# Altium schematic sheet [04] - XBEE.SchDoc("[04] - XBEE")
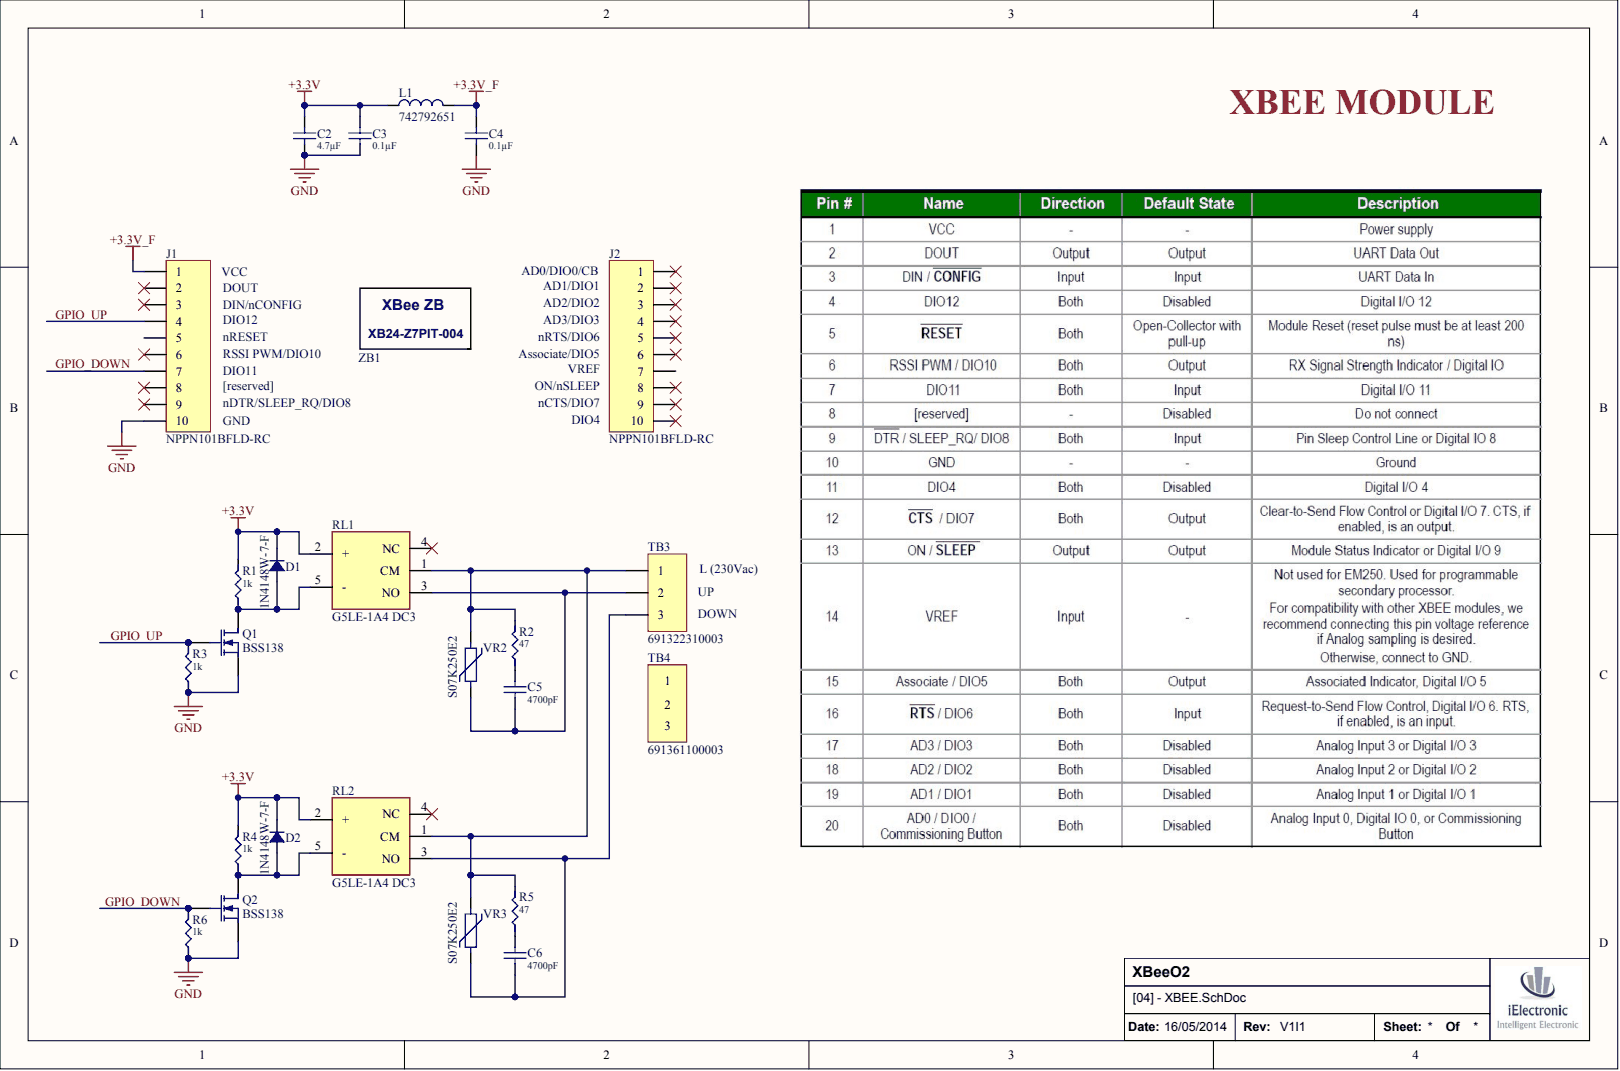
[diagram p0: [04] - XBEE.SchDoc]

## Parts
C2  Capacitance: 4.7µF  (hidden comment: CC0603KRX5R6BB475)
C3  Capacitance: 0.1µF  (hidden comment: CC0402KRX5R6BB104)
C4  Capacitance: 0.1µF  (hidden comment: CC0402KRX5R6BB104)
C5  Capacitance: 4700pF  (hidden comment: CD12ZU2GA472MYNKA)
C6  Capacitance: 4700pF  (hidden comment: CD12ZU2GA472MYNKA)
D1  1N4148W-7-F
D2  1N4148W-7-F
J1  NPPN101BFLD-RC
J2  NPPN101BFLD-RC
L1  742792651
Q1  BSS138
Q2  BSS138
R1  Resistance (Ohms): 1k  (hidden comment: ERJ-3GEYJ102V)
R2  RSF100JB-73-47R  (value not drawn)
R3  Resistance (Ohms): 1k  (hidden comment: ERJ-3GEYJ102V)
R4  Resistance (Ohms): 1k  (hidden comment: ERJ-3GEYJ102V)
R5  RSF100JB-73-47R  (value not drawn)
R6  Resistance (Ohms): 1k  (hidden comment: ERJ-3GEYJ102V)
RL1  G5LE-1A4 DC3
RL2  G5LE-1A4 DC3
TB3  691322310003
TB4  691361100003
VR2  S07K250E2
VR3  S07K250E2
ZB1  XB24-Z7PIT-004

## Nets
+3.3V: C2.2 C3.2 D1.2 D2.2 L1.1 R1.2 R4.2 RL1.2(+) RL2.2(+)
+3.3V_F: C4.2 J1.1 L1.2
GND: C2.1 C3.1 C4.1 J1.10 Q1.3 Q2.3 R3.1 R6.1
GPIO_DOWN: J1.7 Q2.2 R6.2
GPIO_UP: J1.4 Q1.2 R3.2
NetC5_1: C5.1 RL1.3(NO) TB3.2 VR2.1
NetC5_2: C5.2 R2.1
NetC6_1: C6.1 RL2.3(NO) TB3.3 VR3.1
NetC6_2: C6.2 R5.1
NetD1_1: D1.1 Q1.1 R1.1 RL1.5(-)
NetD2_1: D2.1 Q2.1 R4.1 RL2.5(-)
NetR2_2: R2.2 R5.2 RL1.1(CM) RL2.1(CM) TB3.1 VR2.2 VR3.2

Not connected: J1 2 3 5 6 8 9; J2 1 2 3 4 5 6 7 8 9 10; RL1 4(NC); RL2 4(NC)

## Library data of the parts
C2-C4 = (no symbol name): Manufacturer: Yageo; Voltage - Rated: 10V; Tolerance: ±10%; Temperature Coefficient: X5R; .Creator: OGF; Supplier 1: Digi-Key; RoHS: RoHS Compliant; Category: Capacitors; Packaging: Cut Tape (CT); Mounting Type: Surface Mount, MLCC; Operating Temperature: -55°C ~ 85°C; Applications: General Purpose; Ratings: -; Height - Seated (Max): -; Lead Spacing: -; Features: -; Lead Style: -; ComponentLink1URL: http://www.yageo.com/documents/recent/UPY-GPHC_X5R_4V-to-50V_12.pdf; ComponentLink1Description: http://www.yageo.com/documents/recent/UPY-GPHC_X5R_4V-to-50V_12.pdf
  C2: Manufacturer Part Number: CC0603KRX5R6BB475; Stock 1: 2745; Pricing 1: 1=0.15, 10=0.104, 25=0.0756, 100=0.0529, 250=0.04284, 500=0.0378, 1000=0.03214 (EUR); Package / Case: 0603 (1608 Metric); Size / Dimension: 0.063" L x 0.031" W (1.60mm x 0.80mm); Thickness (Max): 0.035" (0.90mm); Supplier Part Number 1: 311-1455-1-ND
  C3 C4: Manufacturer Part Number: CC0402KRX5R6BB104; Stock 1: 928398; Pricing 1: 1=0.08, 10=0.015, 25=0.0104, 100=0.0069, 250=0.00564, 500=0.0048, 1000=0.00374, 2500=0.00336, 5000=0.00311 (EUR); Package / Case: 0402 (1005 Metric); Size / Dimension: 0.039" L x 0.020" W (1.00mm x 0.50mm); Thickness (Max): 0.022" (0.55mm); Supplier Part Number 1: 311-1336-1-ND
C5 C6 = (no symbol name): Manufacturer: TDK Corporation; Voltage - Rated: 400VAC; Tolerance: ±20%; Temperature Coefficient: Z5U; Ratings: X1Y1; .Creator: OGF; Supplier 1: Digi-Key; Manufacturer Part Number: CD12ZU2GA472MYNKA; RoHS: RoHS Compliant; Category: Capacitors; Stock 1: 975; Pricing 1: 1=0.5, 10=0.356, 100=0.2348, 250=0.20004, 500=0.17394, 1000=0.14784, 5000=0.13045, 10000=0.12176, 25000=0.11741 (EUR); Packaging: Bulk; Mounting Type: Through Hole; Operating Temperature: -25°C ~ 125°C; Applications: Safety; Package / Case: Radial, Disc; Size / Dimension: 0.531" Dia (13.50mm); Height - Seated (Max): 0.688" (17.50mm); Thickness (Max): -; Lead Spacing: 0.394" (10.00mm); Features: High Voltage; Lead Style: Straight; ComponentLink1URL: http://product.tdk.com/capacitor/leaddisk/en/documents/leaddisc_commercial_cd_en.pdf; ComponentLink1Description: http://product.tdk.com/capacitor/leaddisk/en/documents/leaddisc_commercial_cd_en.pdf; Supplier Part Number 1: 445-15844-ND
D1 D2 = (no symbol name): Manufacturer: Diodes Inc; Diode Type: Standard; Voltage - DC Reverse (Vr) (Max): 100V; Current - Average Rectified (Io): 150mA; Voltage - Forward (Vf) (Max) @ If: 1.25V @ 150mA; Speed: Small Signal =< 200mA (Io), Any Speed; Reverse Recovery Time (trr): 4ns; Current - Reverse Leakage @ Vr: 1µA @ 75V; Capacitance @ Vr, F: 2pF @ 0V, 1MHz; Thermal Resistance: 315°C/W Ja; .Creator: OGF; Supplier 1: Digi-Key; Manufacturer Part Number: 1N4148W-7-F; RoHS: RoHS Compliant; Category: Discrete Semiconductor Products; Stock 1: 610647; Pricing 1: 1=0.14, 10=0.125, 25=0.1132, 100=0.0815, 250=0.04796, 500=0.0398, 1000=0.02714 (EUR); Packaging: Cut Tape (CT); Mounting Type: Surface Mount; Package / Case: SOD-123; Supplier Device Package: SOD-123; Operating Temperature - Junction: -65°C ~ 150°C; ComponentLink1URL: http://www.diodes.com/datasheets/ds30086.pdf; ComponentLink1Description: http://www.diodes.com/datasheets/ds30086.pdf; Supplier Part Number 1: 1N4148W-FDICT-ND
J1 J2 = (no symbol name): Manufacturer: Sullins Connector Solutions; Connector Type: Header; Number of Positions: 10; Number of Positions Loaded: All; Pitch: 0.079" (2.00mm); .Creator: OGF; Supplier 1: Digi-Key; Manufacturer Part Number: NPPN101BFLD-RC; RoHS: RoHS Compliant; Category: Connectors, Interconnects; Stock 1: 8310; Pricing 1: 1=1.22, 10=1.013, 25=0.938, 50=0.8442, 100=0.7505, 250=0.65672, 500=0.6004, 1000=0.54412, 2500=0.48783 (EUR); Packaging: Bulk; Contact Type: Female Socket; Number of Rows: 1; Row Spacing: -; Mounting Type: Surface Mount; Termination: Solder; Fastening Type: -; Features: -; Contact Finish: Gold; Contact Finish Thickness: Flash; Color: Black; ComponentLink1URL: http://www.sullinscorp.com/images/NPPNxx1BFLx-RC-10489.pdf; ComponentLink1Description: http://www.sullinscorp.com/images/NPPNxx1BFLx-RC-10489.pdf; Supplier Part Number 1: S5902-10-ND
L1 = (no symbol name): Manufacturer: Wurth Electronics Inc; Impedance @ Frequency: 600 Ohm @ 100MHz; Current Rating: 1A; DC Resistance (DCR): 200 mOhm Max; Filter Type: Differential Mode - Single; .Creator: OGF; Supplier 1: Digi-Key; Manufacturer Part Number: 742792651; RoHS: RoHS Compliant; Category: Filters; Stock 1: 98518; Pricing 1: 1=0.34, 10=0.319, 25=0.2976, 100=0.2816, 250=0.26532, 500=0.2545, 1000=0.23824 (EUR); Packaging: Digi-Reel®; Package / Case: 0603 (1608 Metric); Mounting Type: Surface Mount; Height (Max): 0.039" (1.00mm); Size / Dimension: 0.063" L x 0.031" W (1.60mm x 0.80mm); ComponentLink1URL: http://katalog.we-online.de/pbs/datasheet/742792651.pdf; ComponentLink1Description: http://katalog.we-online.de/pbs/datasheet/742792651.pdf; Supplier Part Number 1: 732-1593-6-ND
Q1 Q2 = (no symbol name): Manufacturer: Fairchild Semiconductor; FET Type: MOSFET N-Channel, Metal Oxide; FET Feature: Logic Level Gate; Drain to Source Voltage (Vdss): 50V; Current - Continuous Drain (Id) @ 25° C: 220mA (Ta); Rds On (Max) @ Id, Vgs: 3.5 Ohm @ 220mA, 10V; Vgs(th) (Max) @ Id: 1.5V @ 1mA; Gate Charge (Qg) @ Vgs: 2.4nC @ 10V; Input Capacitance (Ciss) @ Vds: 27pF @ 25V; Power - Max: 360mW; .Creator: OGF; Supplier 1: Digi-Key; RoHS: RoHS Compliant; Category: Discrete Semiconductor Products; Stock 1: 58865; Pricing 1: 1=0.18, 10=0.157, 25=0.1124, 100=0.0875, 250=0.05496, 500=0.04686, 1000=0.03193 (EUR); Packaging: Cut Tape (CT); Mounting Type: Surface Mount; Package / Case: TO-236-3, SC-59, SOT-23-3; Supplier Device Package: SOT-23; ComponentLink1URL: http://www.fairchildsemi.com/ds/BS/BSS138.pdf; ComponentLink1Description: http://www.fairchildsemi.com/ds/BS/BSS138.pdf; Supplier Part Number 1: BSS138CT-ND; Manufacturer Part Number: BSS138
R1 R3 R4 R6 = (no symbol name): Manufacturer: Panasonic Electronic Components; Tolerance: ±5%; Power (Watts): 0.1W, 1/10W; Temperature Coefficient: ±200ppm/°C; .Creator: OGF; Supplier 1: Digi-Key; Manufacturer Part Number: ERJ-3GEYJ102V; RoHS: RoHS Compliant; Category: Resistors; Stock 1: 3526613; Pricing 1: 1=0.08, 50=0.01, 100=0.0077, 250=0.00572, 500=0.00422, 1000=0.00281, 2500=0.00237 (EUR); Packaging: Cut Tape (CT); Composition: Thick Film; Features: -; Package / Case: 0603 (1608 Metric); Supplier Device Package: 0603; Size / Dimension: 0.063" L x 0.031" W (1.60mm x 0.80mm); Height: 0.022" (0.55mm); Number of Terminations: 2; ComponentLink1URL: http://industrial.panasonic.com/www-cgi/jvcr13pz.cgi?E+PZ+3+AOA0001+ERJ3GEYJ102V+7+WW; ComponentLink1Description: http://industrial.panasonic.com/www-cgi/jvcr13pz.cgi?E+PZ+3+AOA0001+ERJ3GEYJ102V+7+WW; Supplier Part Number 1: P1.0KGCT-ND
R2 R5 = (no symbol name): Manufacturer: Yageo; Tolerance: ±5%; Power (Watts): 1W; Temperature Coefficient: ±300ppm/°C; Resistance (Ohms): 47; .Creator: OGF; Supplier 1: Digi-Key; Manufacturer Part Number: RSF100JB-73-47R; RoHS: RoHS Compliant; Category: Resistors; Stock 1: 7037; Pricing 1: 1=0.19, 10=0.163, 25=0.118, 100=0.0636, 250=0.04876, 500=0.03842, 1000=0.02659, 2500=0.02438, 5000=0.02216 (EUR); Packaging: Bulk; Composition: Metal Oxide Film; Features: Flame Proof; Package / Case: Axial; Supplier Device Package: Axial; Size / Dimension: 0.177" Dia x 0.453" L (4.50mm x 11.50mm); Height: -; Number of Terminations: 2; ComponentLink1URL: http://www.yageo.com/documents/recent/Flame-Proof%20Type_RSF.pdf; ComponentLink1Description: http://www.yageo.com/documents/recent/Flame-Proof%20Type_RSF.pdf; Supplier Part Number 1: 47W-1-ND
RL1 RL2 = (no symbol name): Manufacturer: Omron Electronics Inc-EMC Div; Relay Type: General Purpose; Coil Type: Non Latching; Coil Current: 133.3mA; Coil Voltage: 3VDC; Contact Form: SPST-NO (1 Form A); Contact Rating (Current): 10A; Switching Voltage: 250VAC, 125VDC - Max; Turn On Voltage (Max): 2.25 VDC; Turn Off Voltage (Min): 0.3 VDC; Operate Time: 10ms; Release Time: 5ms; Coil Power: 400 mW; Coil Resistance: 22.5 Ohms; .Creator: OGF; Supplier 1: Digi-Key; RoHS: RoHS Compliant; Category: Relays; Stock 1: 56; Pricing 1: 1=1.17, 10=1.103, 25=0.9808, 50=0.9316, 100=0.8826, 250=0.78452, 500=0.7355, 1000=0.68646, 2500=0.6742 (EUR); Packaging: Bulk; Features: Insulation - Class B, Sealed - Fully; Mounting Type: Through Hole; Termination Style: PC Pin; Contact Material: Silver Tin Oxide (AgSnO); Operating Temperature: -40°C ~ 85°C; ComponentLink1URL: http://www.components.omron.com/components/web/PDFLIB.nsf/0/0293ACA6B03161CD86257538007424DB/$file/G5LE_0813.pdf; ComponentLink1Description: http://www.components.omron.com/components/web/PDFLIB.nsf/0/0293ACA6B03161CD86257538007424DB/$file/G5LE_0813.pdf; Supplier Part Number 1: Z3697-ND; Manufacturer Part Number: G5LE-1A4 DC3
TB3 = (no symbol name): Manufacturer: Wurth Electronics Inc; Number of Positions: 3; Pitch: 0.150" (3.81mm); Number of Levels: 1; Header Orientation: 90°, Right Angle; Current: 10A; Voltage: 300V; .Creator: OGF; Supplier 1: Digi-Key; Manufacturer Part Number: 691322310003; RoHS: RoHS Compliant; Category: Connectors, Interconnects; Stock 1: 598; Pricing 1: 1=1.25, 10=0.879, 50=0.7442, 100=0.7409, 250=0.6256, 500=0.59268, 1000=0.52682 (EUR); Packaging: Bulk; Terminal Block Type: Header, Male Pins, Shrouded (4 Side); Positions Per Level: 3; Plug Wire Entry: -; Termination: Solder; Wire Gauge: -; Mounting Type: Through Hole; Features: -; Color: Green; ComponentLink1URL: http://katalog.we-online.de/em/datasheet/6913223100xx.pdf; ComponentLink1Description: http://katalog.we-online.de/em/datasheet/6913223100xx.pdf; Supplier Part Number 1: 732-2089-ND
TB4 = (no symbol name): Manufacturer: Wurth Electronics Inc; Number of Positions: 3; Pitch: 0.138" (3.50mm); Number of Levels: 1; Plug Wire Entry: 180°; Wire Gauge: 16-26 AWG; Current: 10A; Voltage: 300V; .Creator: OGF; Supplier 1: Digi-Key; Manufacturer Part Number: 691361100003; RoHS: RoHS Compliant; Category: Connectors, Interconnects; Stock 1: 499; Pricing 1: 1=2.24, 10=1.699, 25=1.5744, 50=1.4154, 100=1.3808, 250=1.2082, 500=1.10462, 1000=1.00107 (EUR); Packaging: Bulk; Terminal Block Type: Plug, Female Sockets; Positions Per Level: 3; Header Orientation: -; Termination: Screw; Mounting Type: Free Hanging (In-Line); Features: -; Color: Green; ComponentLink1URL: http://katalog.we-online.de/em/datasheet/6913611000xx.pdf; ComponentLink1Description: http://katalog.we-online.de/em/datasheet/6913611000xx.pdf; Supplier Part Number 1: 732-2752-ND
VR2 VR3 = (no symbol name): Manufacturer: EPCOS Inc; Varistor Voltage: 351V; Current - Surge: 1.75kA; Number of Circuits: 1; Maximum AC Volts: 250VAC; Maximum DC Volts: 320VDC; Energy: 25J; .Creator: OGF; Supplier 1: Digi-Key; Manufacturer Part Number: S07K250E2; RoHS: RoHS Compliant; Category: Circuit Protection; Stock 1: 2872; Pricing 1: 1=1.1, 10=0.769, 100=0.4607, 500=0.34552, 1000=0.29178, 5000=0.26107, 10000=0.24571 (EUR); Packaging: Bulk; Package / Case: Disc 7mm; ComponentLink1URL: http://media.digikey.com/pdf/Data%20Sheets/Epcos%20PDFs/AdvanceD_Leaded_Varistors.pdf; ComponentLink1Description: http://media.digikey.com/pdf/Data%20Sheets/Epcos%20PDFs/AdvanceD_Leaded_Varistors.pdf; Supplier Part Number 1: 495-1425-ND
ZB1 = (no symbol name): Manufacturer: Digi International; Data Rate: 250 kbps; Line of Sight Range: 120 m; Output Power: 1 mW; Sensitivity: - 96 dBm; Frequency Band: 2.4 GHz; Antenna Connector Type: PCB; Operating Supply Voltage: 2.1 V to 3.6 V; Supply Current Receiving: 38 mA; Supply Current Transmitting: 35 mA; Product Status: New at Mouser; .Creator: OGF; Supplier 1: Mouser; Manufacturer Part Number: XB24-Z7PIT-004; RoHS: RoHS Compliant; Category: Zigbee / 802.15.4 Modules; Stock 1: 324; Pricing 1: 1=22.95 (AUD); Maximum Operating Temperature: + 85 C; RoHS - Mouser: Y; Minimum Order Quantity: 1; Reeling: False; ComponentLink1URL: http://www.digi.com/pdf/ds_xbeezbmodules.pdf; ComponentLink1Description: http://www.digi.com/pdf/ds_xbeezbmodules.pdf; Supplier Part Number 1: 888-XB24-Z7PIT-004
